# Altium schematic sheet Boot.SchDoc
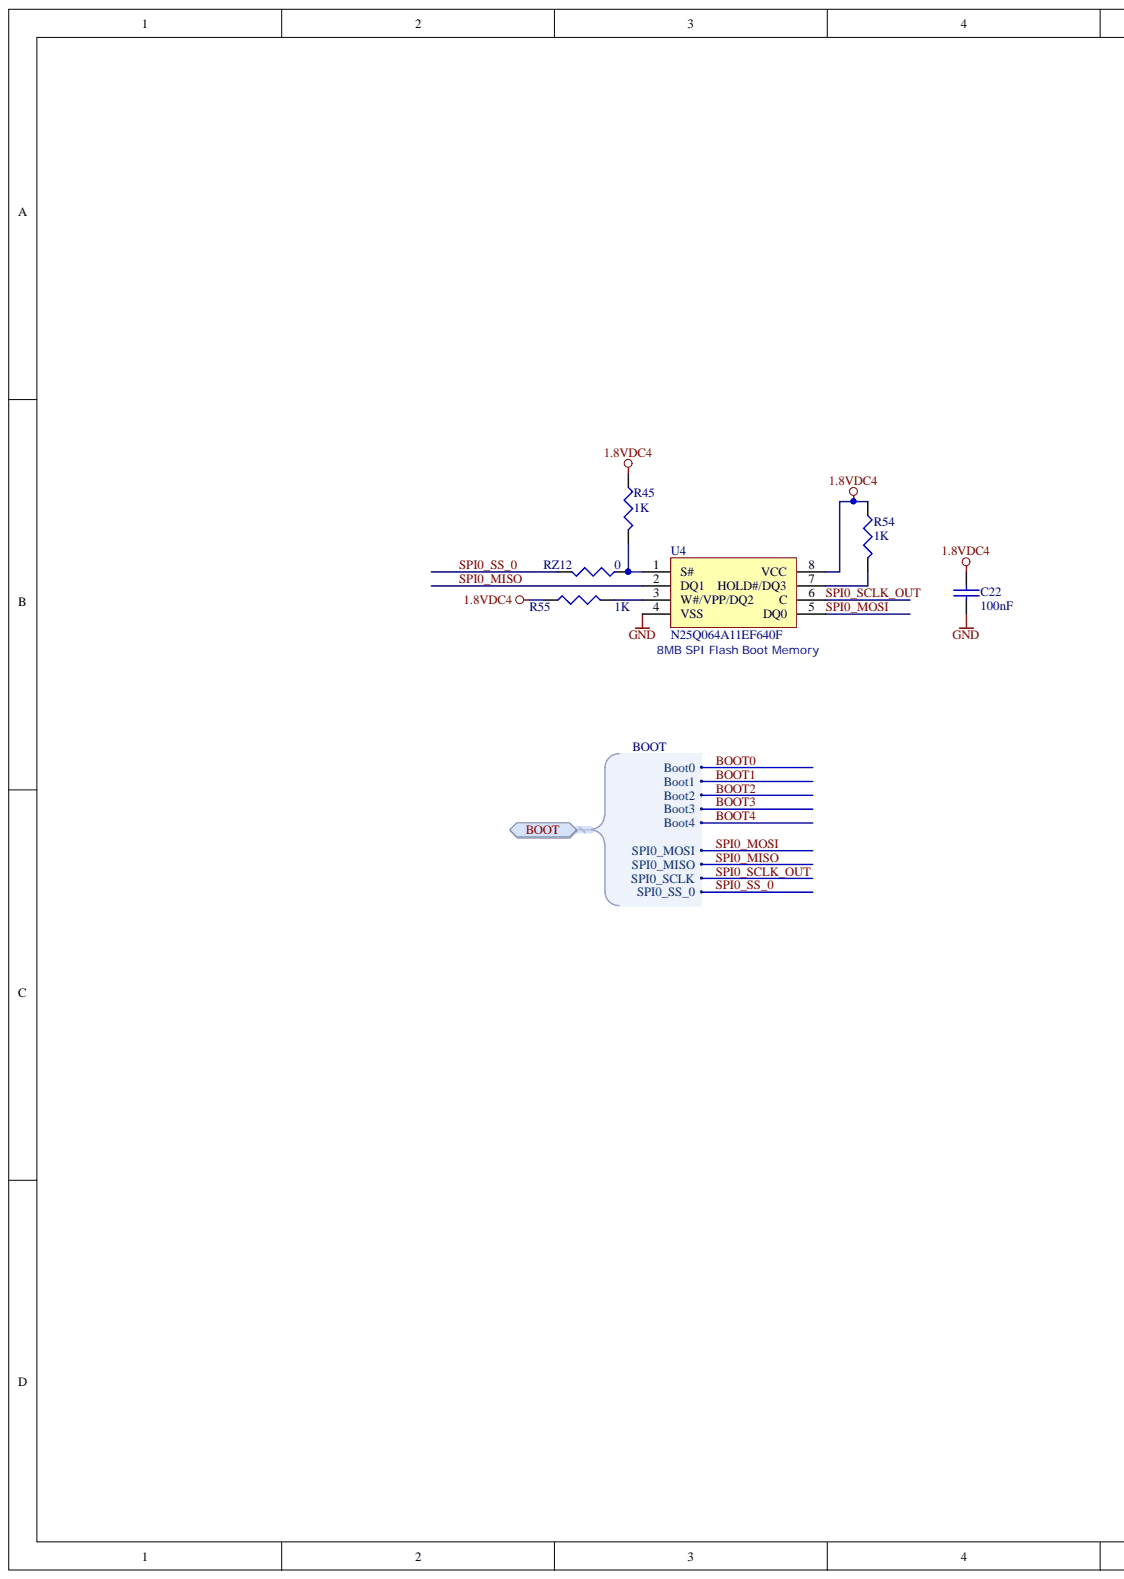
[diagram p0: Boot.SchDoc, left]
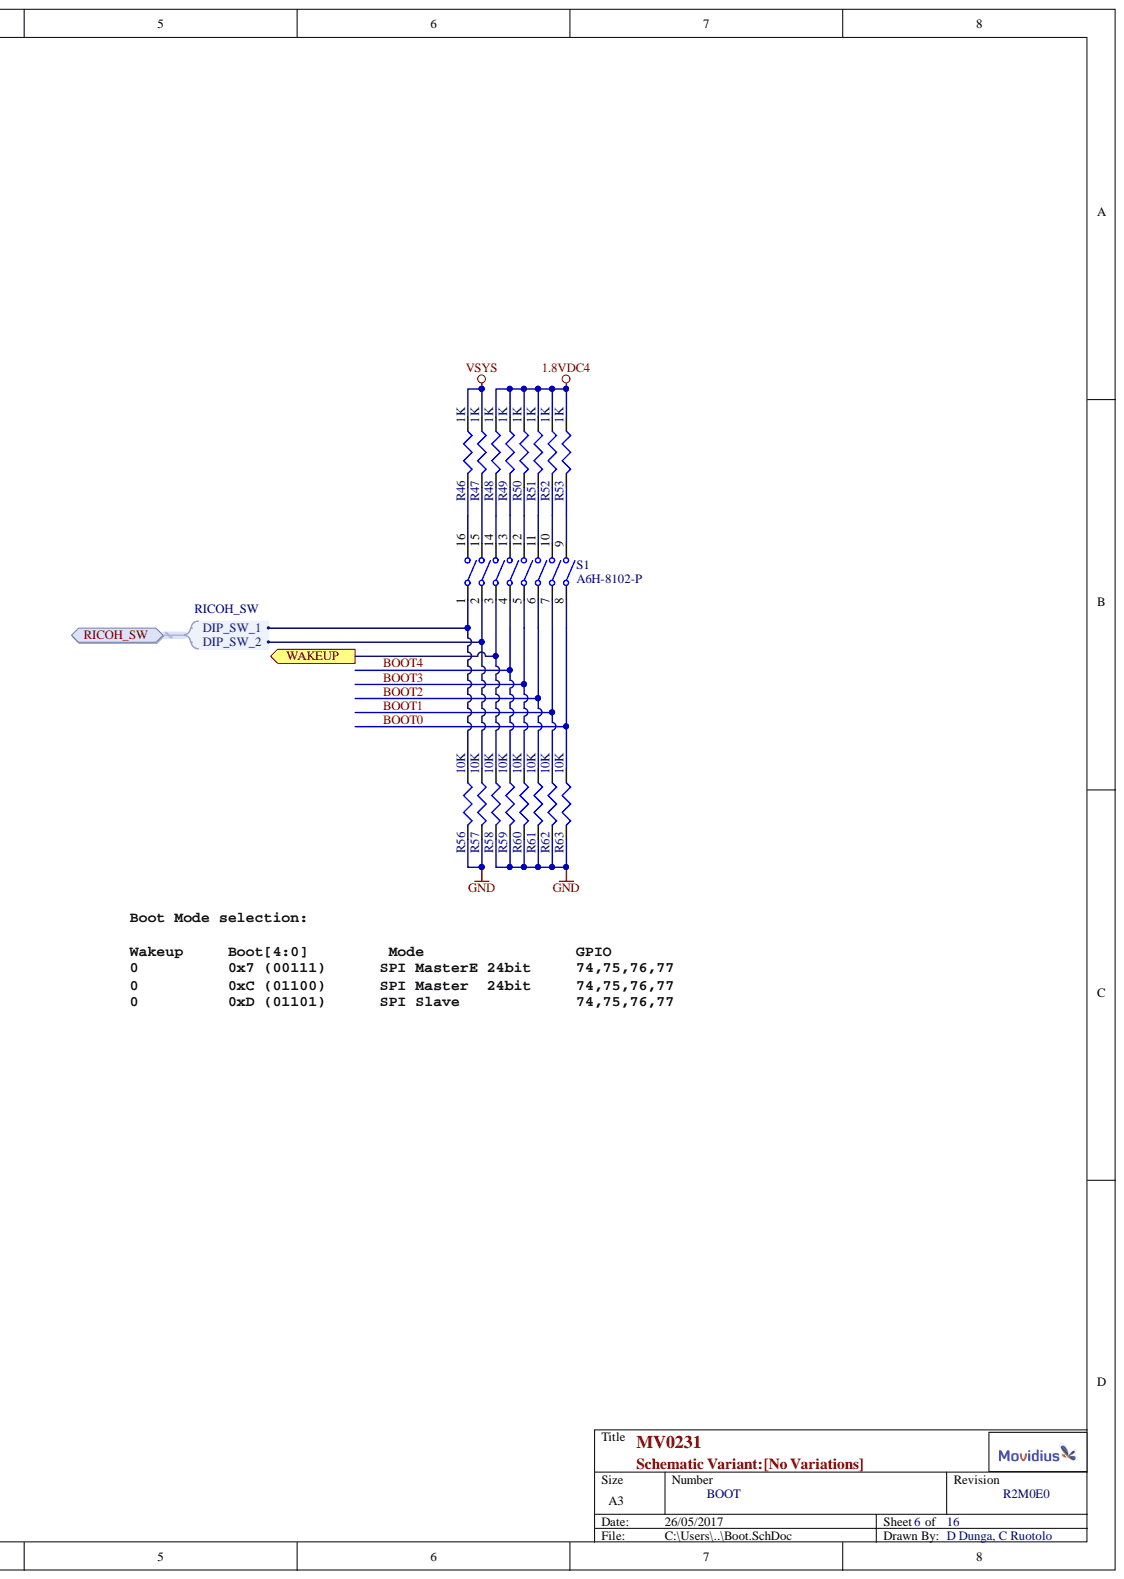
[diagram p1: Boot.SchDoc, right]

## Parts
C22  100nF  [0402]
R45  1K  [0402]
R46  1K  [0402]
R47  1K  [0402]
R48  1K  [0402]
R49  1K  [0402]
R50  1K  [0402]
R51  1K  [0402]
R52  1K  [0402]
R53  1K  [0402]
R54  1K  [0402]
R55  1K  [0402]
R56  10K  [0402]
R57  10K  [0402]
R58  10K  [0402]
R59  10K  [0402]
R60  10K  [0402]
R61  10K  [0402]
R62  10K  [0402]
R63  10K  [0402]
RZ12  0  [0402]
S1  A6H-8102-P  [A6H-8]
U4  N25Q064A11EF640F  [PSON127P600X100_HS-9N]

## Ports
BOOT, BOOT.Boot0, BOOT.Boot1, BOOT.Boot2, BOOT.Boot3, BOOT.Boot4, BOOT.SPI0_MISO, BOOT.SPI0_MOSI, BOOT.SPI0_SCLK, BOOT.SPI0_SS_0, RICOH_SW, RICOH_SW.DIP_SW_1, RICOH_SW.DIP_SW_2, WAKEUP

## Nets
1.8VDC4: C22.2 R45.2 R48.1 R49.1 R50.1 R51.1 R52.1 R53.1 R54.2 R55.2 U4.8(VCC)
GND: C22.1 R56.2 R57.2 R58.2 R59.2 R60.2 R61.2 R62.2 R63.2 U4.4(VSS) U4.9
VSYS: R46.1 R47.1
BOOT0: R63.1 S1.8 ; port BOOT
BOOT1: R62.1 S1.7 ; port BOOT
BOOT2: R61.1 S1.6 ; port BOOT
BOOT3: R60.1 S1.5 ; port BOOT
BOOT4: R59.1 S1.4 ; port BOOT
RICOH_SW.DIP_SW_1: R56.1 S1.1 ; port RICOH_SW
RICOH_SW.DIP_SW_2: R57.1 S1.2 ; port RICOH_SW
SPI0_MISO: U4.2(DQ1) ; port BOOT
SPI0_MOSI: U4.5(DQ0) ; port BOOT
SPI0_SCLK_OUT: U4.6(C) ; port BOOT
SPI0_SS_0: RZ12.2 ; port BOOT
WAKEUP: R58.1 S1.3 ; port WAKEUP
NetR45_1: R45.1 RZ12.1 U4.1(S#)
NetR46_2: R46.2 S1.16
NetR47_2: R47.2 S1.15
NetR48_2: R48.2 S1.14
NetR49_2: R49.2 S1.13
NetR50_2: R50.2 S1.12
NetR51_2: R51.2 S1.11
NetR52_2: R52.2 S1.10
NetR53_2: R53.2 S1.9
NetR54_1: R54.1 U4.7(HOLD#/DQ3)
NetR55_1: R55.1 U4.3(W#/VPP/DQ2)

## Library data of the parts
C22 = CAP: Ceramic Capacitor SMD; DIST: Farnell; DIST PN: 1288282RL; ICCO PN: MV122005; MAN: C SMD 0402; MAN PN: 100nF SMD 0402; Component Kind: Standard
R45-R55 = RES1: Resistor SMD; DIST: DIGIKEY; DIST PN: 311-1.00KHRDKR-ND; ICCO PN: MV122012; MAN: R SMD 0603; MAN PN: 1K SMD 0603; Component Kind: Standard
R56-R63 = RES1: Resistor SMD; DIST: DIGIKEY; DIST PN: 311-10.0KHRDKR-ND; ICCO PN: MV122008; MAN: R SMD 0603; MAN PN: 10K SMD 0603; Component Kind: Standard
RZ12 = RES1: Resistor SMD; DIST: DIGIKEY; DIST PN: 311-0.0GRDKR-ND; ICCO PN: MV148022; MAN: R SMD 0603; Component Kind: Standard; MAN PN: 0 SMD 0603
S1 = A6H-8102-P: Ultra-Low Profile, Half-Pitch, SM, Slide DIP Switch, Flat Actuator, White Striker, 8 Position, SPST, Seal Tape: Embossed Taping Model; DIST: Farnell; DIST PN: 1829492; MAN: Omron; PCB_Layout: Complies with manufacturer's recommendation.; Component Kind: Standard; ComponentLink1Description: Manufacturer Link; ComponentLink1URL: http://www.omron.com/; ComponentLink2Description: Datasheet; ComponentLink2URL: http://www.omron.com/ecb/products/pdf/en-a6h.pdf; DatasheetVersion: Jul-2007; Library Name: OMRON Switch DIP.IntLib; MAN PN: A6H-8102; PackageDescription: Slide DIP Switch; SM; 8 Position SPST; Body 11.39 x 4.5 mm (LxW); Pitch 1.27mm; PackageReference: A6H-8; PackageVersion: Jul-2007; Published: 14-Mar-2008; Publisher: Altium Limited
U4 = N25Q064A11EF640F: IC FLASH 64MBIT 108MHZ; DIST: Arrow; MAN: Micron; Component Kind: Standard; DIST PN: N25Q064A11EF640F; Library Name: MvEoT_R1M0E0_1.SCHLIB; MAN PN: N25Q064A11EF640F
